# Altium schematic sheet EchoFX.SchDoc
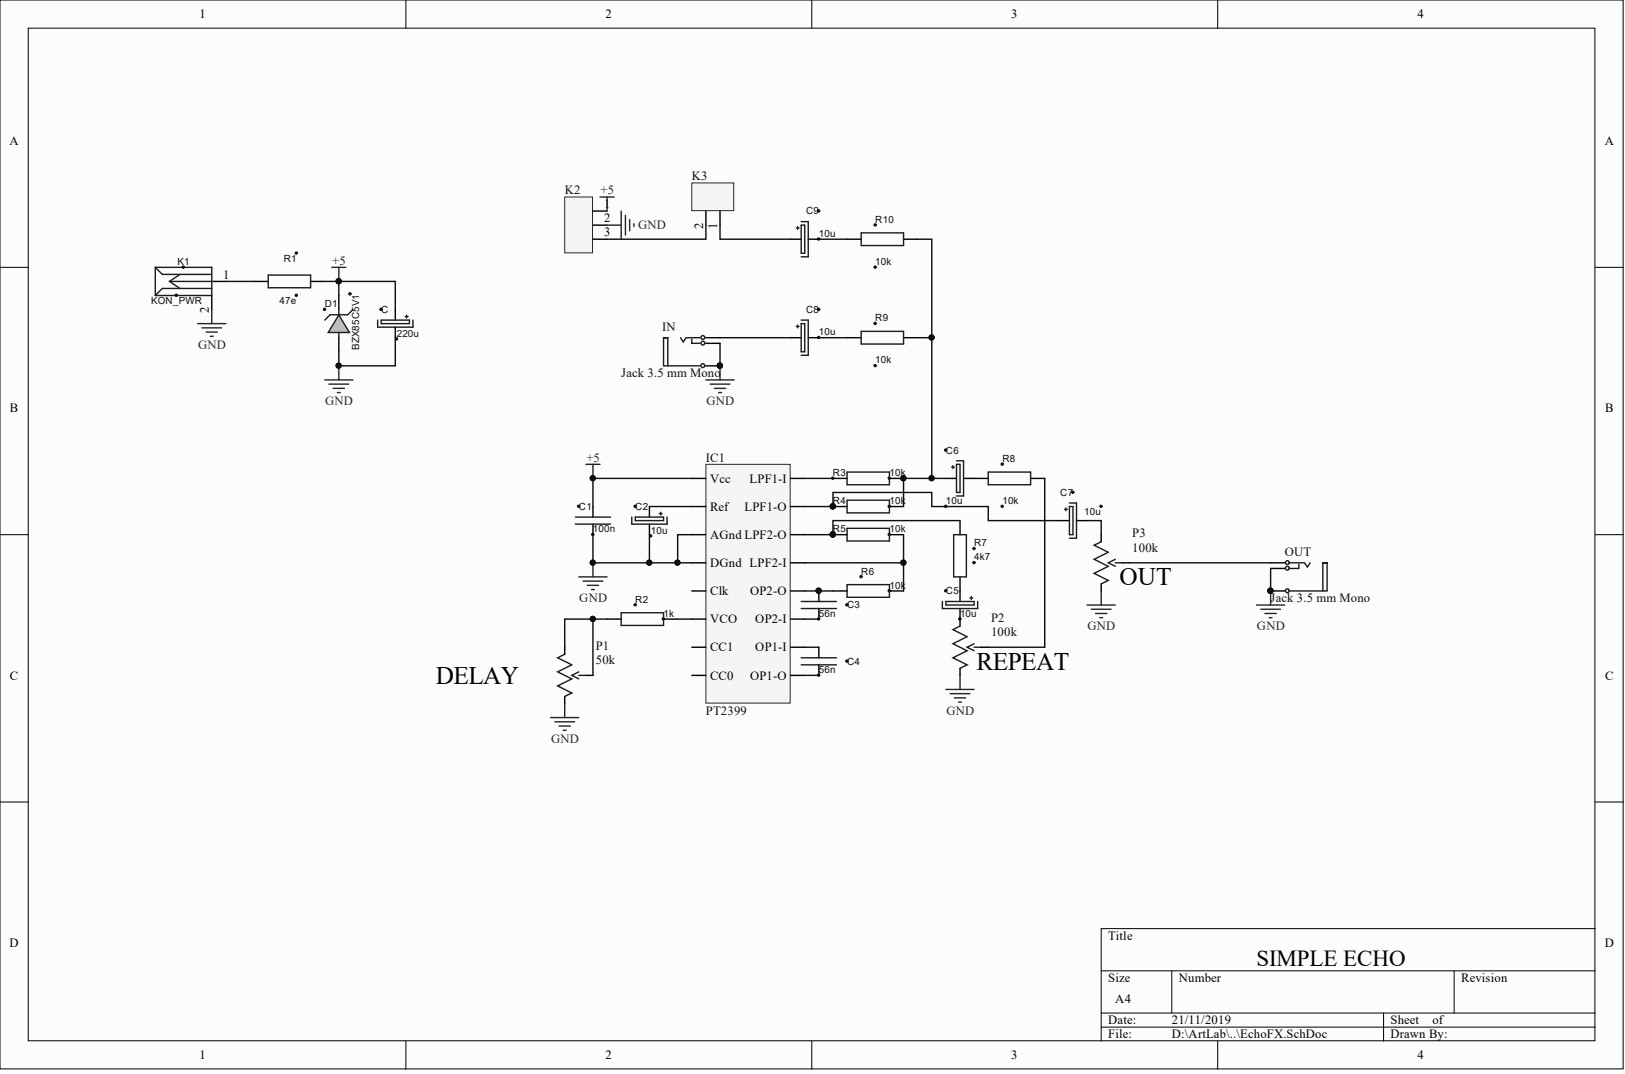
[diagram p0: EchoFX.SchDoc]

## Parts
C  220u  [CAPR5-4X5]
C1  100n  [C Square R5.08 (200mil)]
C2  10u  [C AlElko R2.54 FI5]
C3  56n  [C Square R5.08 (200mil)]
C4  56n  [C Square R5.08 (200mil)]
C5  10u  [C AlElko R2.54 FI5]
C6  10u  [C AlElko R2.54 FI5]
C7  10u  [C AlElko R2.54 FI5]
C8  10u  [C AlElko R2.54 FI5]
C9  10u  [C AlElko R2.54 FI5]
D1  BZX85C5V1  [DO 35 (300MILS)]
IC1  PT2399  [DIP16]
IN  Jack 3.5 mm Mono  [K3632 - Jack]
K1  KON_PWR  [_KON_PWR_KON_PWR(Primary)]
K2  H1x3  [H1x3]  (value not drawn)
K3  H1x2  [H1x2]  (value not drawn)
OUT  Jack 3.5 mm Mono  [K3632 - Jack]
P1  50k  [PDB181- P]
P2  100k  [PDB181- P]
P3  100k  [PDB181- P]
R1  47e  [R400]
R2  1k  [R300]
R3  10k  [R300]
R4  10k  [R300]
R5  10k  [R300]
R6  10k  [R300]
R7  4k7  [R300]
R8  10k  [R300]
R9  10k  [R300]
R10  10k  [R300]

## Nets
+5: C.1 C1.2 D1.K IC1.1(Vcc) K2.1 R1.1
GND: C.2 C1.1 C2.2 D1.A IC1.3(AGnd) IC1.4(DGnd) IN.1 IN.3 K1.2 K2.2 OUT.1 OUT.3 P1.1 P2.1 P3.1
NetC2_1: C2.1 IC1.2(Ref)
NetC3_1: C3.1 IC1.11(OP2-I)
NetC3_2: C3.2 IC1.12(OP2-O) R6.1
NetC4_1: C4.1 IC1.9(OP1-O)
NetC4_2: C4.2 IC1.10(OP1-I)
NetC5_1: C5.1 R7.2
NetC5_2: C5.2 P2.3
NetC6_1: C6.1 R3.1 R4.1 R9.2 R10.2
NetC6_2: C6.2 R8.2
NetC7_1: C7.1 IC1.15(LPF1-O) R4.2
NetC7_2: C7.2 P3.3
NetC8_1: C8.1 IN.2
NetC8_2: C8.2 R9.1
NetC9_1: C9.1 K3.1
NetC9_2: C9.2 R10.1
NetIC1_6: IC1.6(VCO) R2.2
NetIC1_13: IC1.13(LPF2-I) R5.2 R6.2
NetIC1_14: IC1.14(LPF2-O) R5.1 R7.1
NetIC1_16: IC1.16(LPF1-I) R3.2
NetK1_1: K1.1 R1.2
NetK2_3: K2.3 K3.2
NetOUT_2: OUT.2 P3.2
NetP1_2: P1.2 P1.3 R2.1
NetP2_2: P2.2 R8.1

Not connected: IC1 5(Clk) 7(CC1) 8(CC0)

## Library data of the parts
C C2 C5-C9 = C+: Capacitor Polarized; Component Kind: Standard; Library Name: General.IntLib
  C: Value: 220u
  C2 C5-C9: Value: 10u
C1 C3 C4 = C: Capacitor; Component Kind: Standard; Library Name: General.IntLib
  C1: Value: 100n
  C3 C4: Value: 56n
IC1 = PT2399 Echo-Delay: Component Kind: Standard; Description: PT2399 Echo-Delay; Library Name: Eurorack.IntLib
IN OUT = Mono Jack 3.5 mm 3-pin: Component Kind: Standard; ComponentLink1Description: Datasheet; ComponentLink1URL: https://si.farnell.com/cliff-electronic-components/fc68131/stereo-jack-3-5mm-3pos-pcb/dp/2518188; ComponentLink1URL: http://si.farnell.com/webapp/wcs/stores/servlet/ProductDisplay?catalogId=10001&langId=386&urlRequestType=Base&partNumber=1267400&storeId=10175; Description: Mono Jack 3.5 mm 3-pin; Library Name: Eurorack.IntLib
K2 = H1x3: Header 1x3 (male); Component Kind: Standard; Library Name: Kon_Tipk.IntLib
K3 = H1x2: Header 1x2 (male); Component Kind: Standard; Library Name: Kon_Tipk.IntLib
P1-P3 = Potentiometer Rotary: Component Kind: Standard; ComponentLink1description: datasheet; ComponentLink1URL: https://www.bourns.com/docs/product-datasheets/PDB18.pdf; ComponentLink1URL: http://si.farnell.com/webapp/wcs/stores/servlet/Search?catalogId=15001&langId=386&storeId=10175&categoryId=700000005476&sort=P_PRICE&pageSize=25&beginIndex=1&showResults=true&pf=110131914,113164069; Description: Potentiometer Rotary; Library Name: Eurorack.IntLib
  P1: Value: 50k
  P2 P3: Value: 100k
R1-R10 = R: Component Kind: Standard; Description: R; Library Name: General.IntLib
  R1: Value: 47e
  R2: Value: 1k
  R3-R6 R8-R10: Value: 10k
  R7: Value: 4k7
D1 = BZX85C5V1: Component Kind: Standard; Description: BZX85C5V1; Library Name: SemiCond.IntLib
K1 = KON_PWR: Component Kind: Standard; Description: KON_PWR; Library Name: Kon_Tipk.IntLib
